# Altium schematic sheet Sheet1.SchDoc(Sheet1)
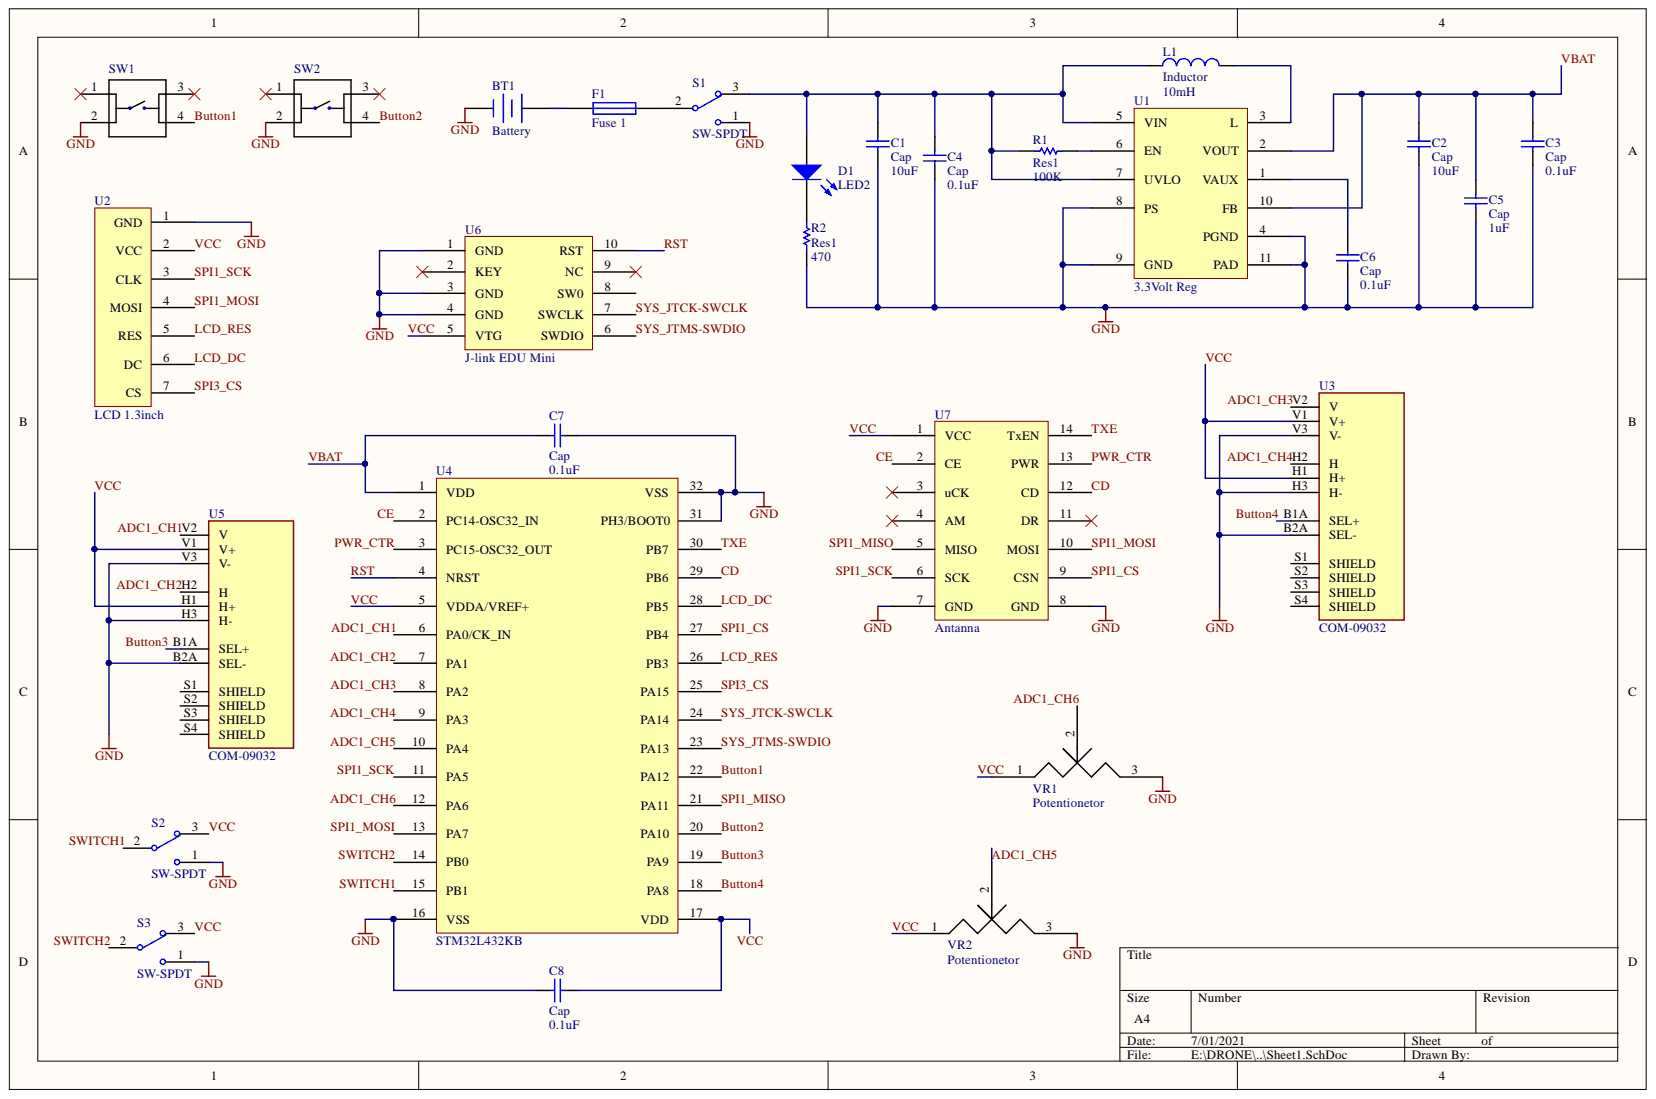
[diagram p0: Sheet1.SchDoc]

## Parts
BT1  Battery  [BAT-2]
C1  Cap  value 10uF  [RESC0603]
C2  Cap  value 10uF  [RESC0603]
C3  Cap  value 0.1uF  [RESC0603]
C4  Cap  value 0.1uF  [RESC0603]
C5  Cap  value 1uF  [RESC0603]
C6  Cap  value 0.1uF  [RESC0603]
C7  Cap  value 0.1uF  [RESC0603]
C8  Cap  value 0.1uF  [RESC0603]
D1  LED2  [LED1]
F1  Fuse 1  [Resistor]
L1  Inductor  value 10mH  [RESC0805]
R1  Res1  value 100K  [RESC0603]
R2  Res1  value 470  [RESC0603]
S1  SW-SPDT  [Toggle switch]
S2  SW-SPDT  [Toggle switch]
S3  SW-SPDT  [Toggle switch]
SW1  1825910-6  [PUSHBUTTON SWITCH - duplicate]  (value not drawn)
SW2  1825910-6  [PUSHBUTTON SWITCH - duplicate]  (value not drawn)
U1  3.3Volt Reg  [VREG_V62/16624-01YE]
U2  LCD 1.3inch  [LCD]
U3  COM-09032  [XDCR_COM-09032]
U4  STM32L432KB  [UFQFPN32]
U5  COM-09032  [XDCR_COM-09032]
U6  J-link EDU Mini  [10 pin vertical]
U7  Antanna  [Antanna]
VR1  Potentionetor  [potentiometer]
VR2  Potentionetor  [potentiometer]

## Nets
GND: BT1.2 C1.1 C2.1 C3.1 C4.1 C5.1 C6.1 C7.1 C8.2 R2.1 S1.1 S2.1 S3.1 SW1.2 SW2.2 U1.4(PGND) U1.8(PS) U1.9(GND) U1.11(PAD) U2.1(GND) U3.B2A(SEL-) U3.H3(H-) U3.V3(V-) U4.16(VSS) U4.31(PH3/BOOT0) U4.32(VSS) U5.B2A(SEL-) U5.H3(H-) U5.V3(V-) U6.1(GND) U6.3(GND) U6.4(GND) U7.7(GND) U7.8(GND) VR1.3 VR2.3
ADC1_CH1: U4.6(PA0/CK_IN) U5.V2(V)
ADC1_CH2: U4.7(PA1) U5.H2(H)
ADC1_CH3: U3.V2(V) U4.8(PA2)
ADC1_CH4: U3.H2(H) U4.9(PA3)
ADC1_CH5: U4.10(PA4) VR2.2
ADC1_CH6: U4.12(PA6) VR1.2
Button1: SW1.4 U4.22(PA12)
Button2: SW2.4 U4.20(PA10)
Button3: U4.19(PA9) U5.B1A(SEL+)
Button4: U3.B1A(SEL+) U4.18(PA8)
CD: U4.29(PB6) U7.12(CD)
CE: U4.2(PC14-OSC32_IN) U7.2(CE)
LCD_DC: U2.6(DC) U4.28(PB5)
LCD_RES: U2.5(RES) U4.26(PB3)
PWR_CTR: U4.3(PC15-OSC32_OUT) U7.13(PWR)
RST: U4.4(NRST) U6.10(RST)
SPI1_CS: U4.27(PB4) U7.9(CSN)
SPI1_MISO: U4.21(PA11) U7.5(MISO)
SPI1_MOSI: U2.4(MOSI) U4.13(PA7) U7.10(MOSI)
SPI1_SCK: U2.3(CLK) U4.11(PA5) U7.6(SCK)
SPI3_CS: U2.7(CS) U4.25(PA15)
SWITCH1: S2.2 U4.15(PB1)
SWITCH2: S3.2 U4.14(PB0)
SYS_JTCK-SWCLK: U4.24(PA14) U6.7(SWCLK)
SYS_JTMS-SWDIO: U4.23(PA13) U6.6(SWDIO)
TXE: U4.30(PB7) U7.14(TxEN)
VBAT: C2.2 C3.2 C5.2 C7.2 U1.2(VOUT) U1.10(FB) U4.1(VDD)
VCC: C8.1 S2.3 S3.3 U2.2(VCC) U3.H1(H+) U3.V1(V+) U4.5(VDDA/VREF+) U4.17(VDD) U5.H1(H+) U5.V1(V+) U6.5(VTG) U7.1(VCC) VR1.1 VR2.1
NetBT1_1: BT1.1 F1.1
NetC1_2: C1.2 C4.2 D1.1 L1.1 R1.1 S1.3 U1.5(VIN) U1.7(UVLO)
NetC6_2: C6.2 U1.1(VAUX)
NetD1_2: D1.2 R2.2
NetF1_2: F1.2 S1.2
NetL1_2: L1.2 U1.3(L)
NetR1_2: R1.2 U1.6(EN)

Not connected: SW1 1 3; SW2 1 3; U3 S1(SHIELD) S2(SHIELD) S3(SHIELD) S4(SHIELD); U5 S1(SHIELD) S2(SHIELD) S3(SHIELD) S4(SHIELD); U6 2(KEY) 8(SW0) 9(NC); U7 3(uCK) 4(AM) 11(DR)

## Library data of the parts
BT1 = Battery: Multicell Battery; Published: 24-Mar-1999; PackageReference: BAT-2; PackageDescription: Battery; 2 Leads; Library Name: Miscellaneous Devices.IntLib; LatestRevisionNote: Re-released for DXP Platform.; LatestRevisionDate: 17-Jul-2002; Component Kind: Standard; Publisher: Altium Limited
C1-C6 C8 = Cap: Capacitor; Simulation: CAP; Signal Integrity: Cap; Published: 8-Jun-2000; PackageReference: RAD-0.3; PackageDescription: Radial Cap, Thru-Hole; 2 Leads; 0.3 in Pin Spacing; Library Name: Miscellaneous Devices.IntLib; LatestRevisionNote: Re-released for DXP Platform.; LatestRevisionDate: 17-Jul-2002; Component Kind: Standard; Publisher: Altium Limited
C7 = Cap: Capacitor; Value: 0.1uF; Simulation: CAP; Signal Integrity: Cap; Published: 8-Jun-2000; PackageReference: RAD-0.3; PackageDescription: Radial Cap, Thru-Hole; 2 Leads; 0.3 in Pin Spacing; Library Name: Miscellaneous Devices.IntLib; LatestRevisionNote: Re-released for DXP Platform.; LatestRevisionDate: 17-Jul-2002; Component Kind: Standard; Publisher: Altium Limited
D1 = LED2: Typical RED, GREEN, YELLOW, AMBER GaAs LED; Simulation: LED2; Published: 8-Jun-2000; PackageVersion: Feb-2002; PackageReference: 3.2X1.6X1.1; PackageDescription: SM LED; 2 Flat Leads; Library Name: Miscellaneous Devices.IntLib; LatestRevisionNote: Re-released for DXP Platform.; LatestRevisionDate: 17-Jul-2002; Component Kind: Standard; Publisher: Altium Limited
F1 = Fuse 1: Fuse; Simulation: FUSE; Published: 8-Jun-2000; PackageReference: PIN-W2/E2.8; PackageDescription: Thru-Hole; 2 Leads; Library Name: Miscellaneous Devices.IntLib; LatestRevisionNote: Re-released for DXP Platform.; LatestRevisionDate: 17-Jul-2002; Component Kind: Standard; Publisher: Altium Limited
L1 = Inductor: Value: 10mH; Code_IEC: 1005; Simulation: INDUCTOR; Signal Integrity: Inductor; Published: 8-Jun-2000; PackageReference: 0402-A; PackageDescription: Chip Inductor; Library Name: Miscellaneous Devices.IntLib; LatestRevisionNote: IPC-7351 Footprint Added.; LatestRevisionDate: 29-May-2009; Description: Inductor; Component Kind: Standard; Code_JEITA: 0402; Publisher: Altium Limited
R1 R2 = Res1: Resistor; Simulation: RESISTOR; Signal Integrity: Res; Published: 8-Jun-2000; PackageReference: AXIAL-0.3; PackageDescription: Axial Device, Thru-Hole; 2 Leads; 0.3 in Pin Spacing; Library Name: Miscellaneous Devices.IntLib; LatestRevisionNote: Re-released for DXP Platform.; LatestRevisionDate: 17-Jul-2002; Component Kind: Standard; Publisher: Altium Limited
S1-S3 = SW-SPDT: SPDT Subminiature Toggle Switch, Right Angle Mounting, Vertical Actuation; Published: 24-Mar-1999; PackageReference: TL36WW15050; PackageDescription: Switch; 4 Leads; Library Name: Miscellaneous Devices.IntLib; LatestRevisionNote: Schematic revised, PCB Footprint replaced.; LatestRevisionDate: 17-Dec-2003; DatasheetVersion: 05-Dec-2000; ComponentLink1URL: http://www.apem.com; ComponentLink1Description: Manufacturer Link; Component Kind: Standard; Publisher: Altium Limited
SW1 SW2 = 1825910-6: 6MM TACT SWITCH, HIGH TEMP; MF: TE Connectivity; Contact_Current_Rating: 50 mA; Configuration_Pole-Throw: Single Pole - Single Throw; TE_purchase_URL: https://www.te.com/usa-en/product-1825910-6.html?te_bu=Cor&te_type=disp&te_campaign=seda_glo_cor-seda-global-disp-prtnr-fy19-seda-model-bom-cta_sma-317_1&elqCampaignId=32493; SnapEDA_Link: https://www.snapeda.com/parts/1825910-6/TE%20Connectivity%20ALCOSWITCH%20Switches/view-part/?ref=snap; Package: None; MP: 1825910-6; Library Name: Schlib1.SchLib; EU_RoHS_Compliance: Compliant; Digi-Key_Purchase_URL: https://www.digikey.com.au/product-detail/en/te-connectivity-alcoswitch-switches/1825910-6/450-1650-ND/1632536?utm_source=snapeda&utm_medium=aggregator&utm_campaign=symbol; Digi-Key_Part_Number: 450-1650-ND; Component Kind: Standard
U3 U5 = COM-09032: Joystick, 2 - Axis Analog _Resistive_ Output; MF: SparkFun Electronics; SnapEDA_Link: https://www.snapeda.com/parts/COM-09032/SparkFun%20Electronics/view-part/888157/?ref=snap; Price: None; Package: SparkFun Electronics; MP: COM-09032; Library Name: Schlib_Controller.SchLib; Component Kind: Standard; Availability: Unavailable
U1 = 3.3Volt Reg: Library Name: Schlib_Controller.SchLib; Component Kind: Standard
U2 = LCD 1.3inch: Library Name: Schlib_Controller.SchLib; Component Kind: Standard
U4 = STM32L432KB: Library Name: Schlib_Controller.SchLib; Component Kind: Standard
U6 = J-link EDU Mini: Library Name: Schlib1.SchLib; Component Kind: Standard
U7 = Antanna: Library Name: Schlib_Controller.SchLib; Component Kind: Standard
VR1 VR2 = Potentionetor: Library Name: Schlib_Controller.SchLib; Component Kind: Standard
